# Altium schematic sheet MCU_Power.SchDoc(MCU_Power)
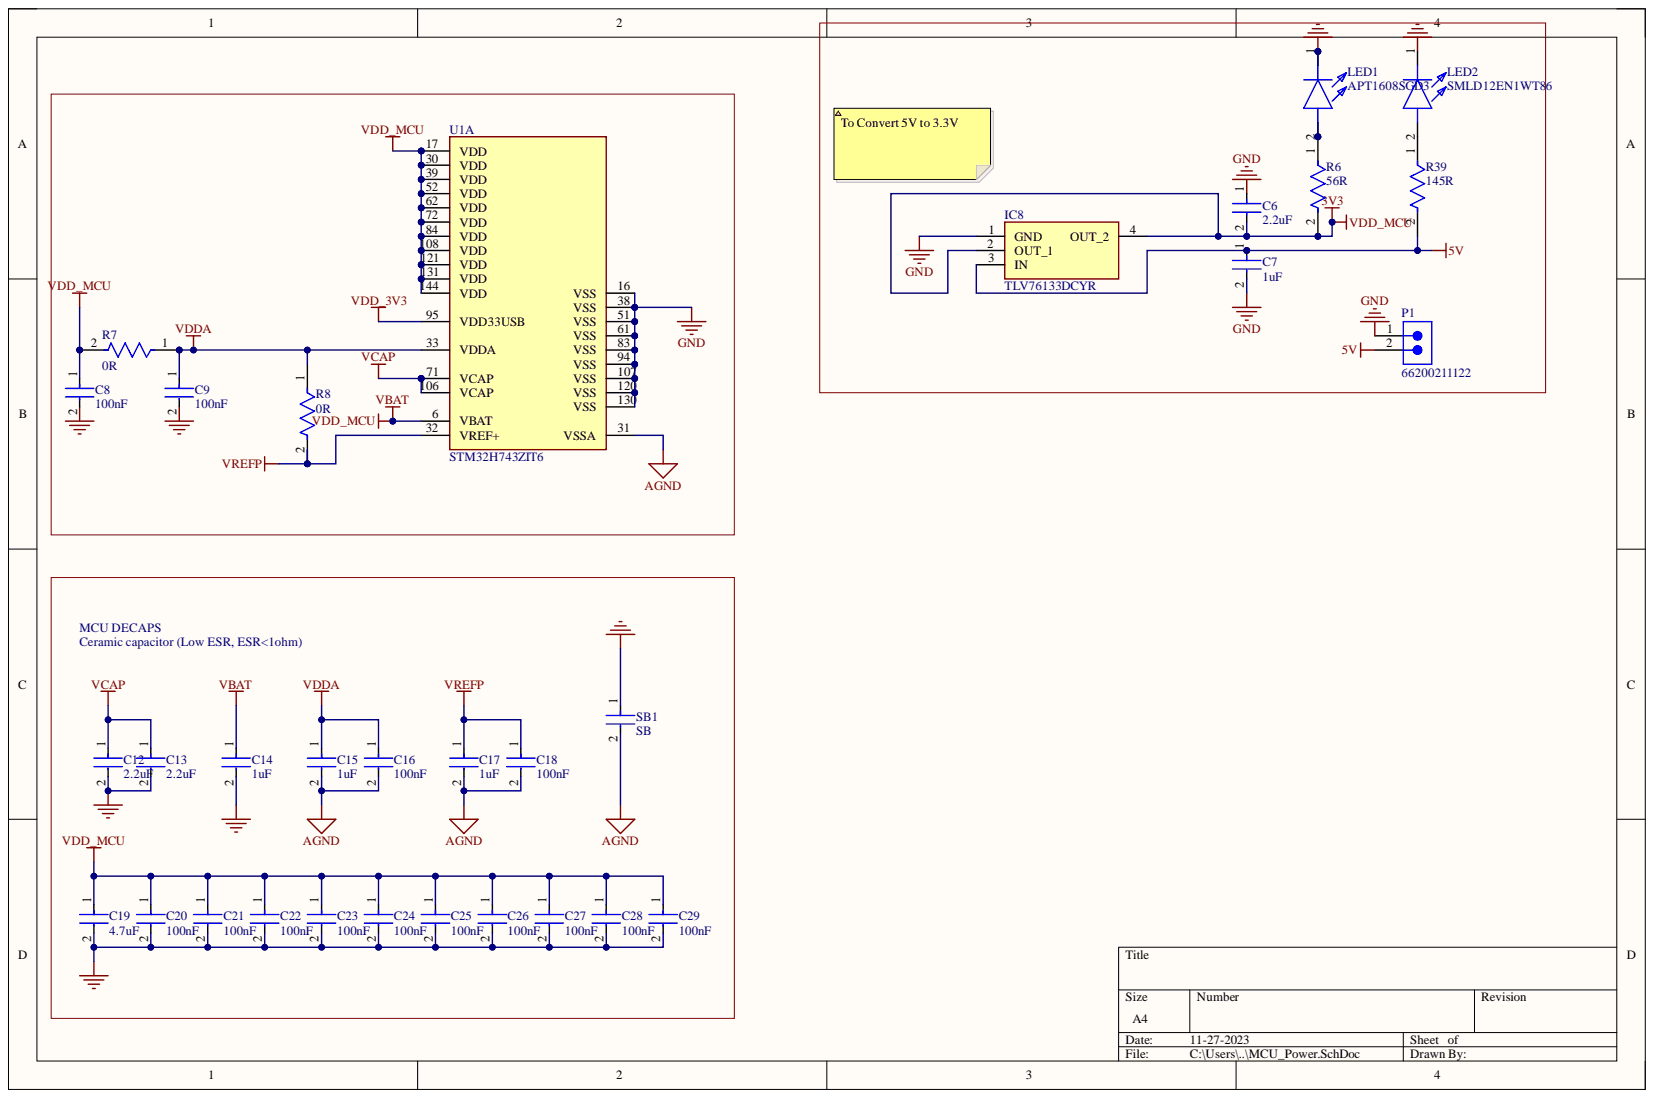
[diagram p0: MCU_Power.SchDoc]

## Parts
C6  2.2uF  [FP-C0603C-CF-MFG]
C7  1uF  [FP-C0603C-CF-MFG]
C8  100nF  [FP-C0402-BB-MFG]
C9  100nF  [FP-C0402-BB-MFG]
C12  2.2uF  [FP-C0603C-CF-MFG]
C13  2.2uF  [FP-C0603C-CF-MFG]
C14  1uF  [FP-C0603C-CF-MFG]
C15  1uF  [FP-C0603C-CF-MFG]
C16  100nF  [FP-C0603C-CF-MFG]
C17  1uF  [FP-C0603C-CF-MFG]
C18  100nF  [FP-C0603C-CF-MFG]
C19  4.7uF  [FP-C0603C-CF-MFG]
C20  100nF  [FP-C0402-BB-MFG]
C21  100nF  [FP-C0402-BB-MFG]
C22  100nF  [FP-C0402-BB-MFG]
C23  100nF  [FP-C0402-BB-MFG]
C24  100nF  [FP-C0402-BB-MFG]
C25  100nF  [FP-C0402-BB-MFG]
C26  100nF  [FP-C0402-BB-MFG]
C27  100nF  [FP-C0402-BB-MFG]
C28  100nF  [FP-C0402-BB-MFG]
C29  100nF  [FP-C0402-BB-MFG]
IC8  TLV76133DCYR  [SOT230P700X180-4N]
LED1  APT1608SGD3  [LEDC1608X85N]
LED2  SMLD12EN1WT86  [LEDM168X65N]
P1  66200211122  [66200211122]
R6  56R  [FP-RT0603-MFG]
R7  0R  [FP-RT0603-MFG]
R8  0R  [FP-RT0603-MFG]
R39  145R  [FP-RT0603-MFG]
SB1  SB  [FP-C0603C-CF-MFG]
U1  STM32H743ZIT6  [FP-LQFP144-1A-MFG]  (part A)

## Nets
3V3: C6.2 C8.1 C14.1 C19.1 C20.1 C21.1 C22.1 C23.1 C24.1 C25.1 C26.1 C27.1 C28.1 C29.1 IC8.2(OUT_1) IC8.4(OUT_2) R6.2 R7.2 U1.6(VBAT) U1.17(VDD) U1.30(VDD) U1.39(VDD) U1.52(VDD) U1.62(VDD) U1.72(VDD) U1.84(VDD) U1.108(VDD) U1.121(VDD) U1.131(VDD) U1.144(VDD)
5V: C7.1 IC8.3(IN) P1.2 R39.2
AGND: C15.2 C16.2 C17.2 C18.2 SB1.2 U1.31(VSSA)
GND: C6.1 C7.2 C8.2 C9.2 C12.2 C13.2 C14.2 C19.2 C20.2 C21.2 C22.2 C23.2 C24.2 C25.2 C26.2 C27.2 C28.2 C29.2 IC8.1(GND) LED1.1 LED2.1 P1.1 SB1.1 U1.16(VSS) U1.38(VSS) U1.51(VSS) U1.61(VSS) U1.83(VSS) U1.94(VSS) U1.107(VSS) U1.120(VSS) U1.130(VSS)
VCAP: C12.1 C13.1 U1.71(VCAP) U1.106(VCAP)
VDD_3V3: U1.95(VDD33USB)
VDDA: C9.1 C15.1 C16.1 R7.1 R8.1 U1.33(VDDA)
VREFP: C17.1 C18.1 R8.2 U1.32(VREF+)
NetLED1_2: LED1.2 R6.1
NetLED2_2: LED2.2 R39.1

## Library data of the parts
C6 C7 C12-C19 = CMP-2006-03061-2: CAP CER 0.1UF 50V X7R 0603; Manufacturer: KEMET; Manufacturer Part Number: C0603C104K5RACTU; Automotive Grade: Grade 1; Capacitance: 0.10 μF; Material: Ceramic; Temperature Coefficient: 30ppm/°C; Tolerance: 0.1; Value: 0.10 μF; Automotive: Yes; Category: Cap; Component Kind: Standard; ComponentLink2Description: Datasheet; ComponentLink2URL: https://api.kemet.com/component-edge/download/datasheet/C0603C100J5GACAUTO.pdf; Device Class L1: Passive Components; Device Class L2: Capacitors; Device Class L3: Ceramic Capacitors; Digikey Description: CAP CER 0.1UF 50V X7R 0603; Height: 0.87mm; Lead Free: Yes; Library Name: Altium Content Vault; Octopart: https://octopart.com/c0603c104k5ractu-kemet-139244; Package: C0603C-CF; RoHS: TRUE; Temperature: -55°C to +125°C; Temperature Characteristic: X7R; Temperature Range High: +125°C; Temperature Range Low: -55°C; Voltage Rating: 50 V
C8 C9 C20-C29 = CMP-025-000008-1: CAP CER 0.1UF 10V X5R 0402; Manufacturer: KEMET; Manufacturer Part Number: C0402C104K8PACTU; Capacitance: 0.10 µF; Material: Ceramic; Temperature Coefficient: 15%; Tolerance: 0.1; Value: 0.10 µF; Automotive: No; Category: Cap; Component Kind: Standard; Component Type: Standard; Design Item ID: CMP-2008-02046-2; Device Class L1: Passive Components; Device Class L2: Capacitors; Device Class L3: Ceramic Capacitors; Digikey Description: CAP CER 0.1UF 10V X5R 0402; Height: 0.55mm; Ipc Land Pattern Name: CAPC10050X50; Lead Free: Yes; Library Name: DH08; Mouser Description: Capacitor 100 nF 10 VDC 0402; Octopart: https://octopart.com/c0402c104k8pactu-kemet-140039; Package: C0402-BB; Pin Count: 2; RoHS: TRUE; Simulation: C_Capacitor_Default; Temperature: -55°C to +125°C; Temperature Characteristic: X5R; Temperature Range High: +125°C; Temperature Range Low: -55°C; Voltage Rating: 10 V; Source: DH07_Optical_Localization_Sensor_V2_Main_1.SCHLIB
IC8 = TLV76133DCYR: Integrated Circuit; Manufacturer_Name: Texas Instruments; Arrow Part Number: TLV76133DCYR; Arrow Price/Stock: https://www.arrow.com/en/products/tlv76133dcyr/texas-instruments?region=nac; Component Kind: Standard; Datasheet Link: https://www.ti.com/lit/ds/symlink/tlv761.pdf?ts=1679984111185&ref_url=https%253A%252F%252Fwww.ti.com%252Fsitesearch%252Fen-us%252Fdocs%252Funiversalsearch.tsp%253FlangPref%253Den-US%2526searchTerm%253DTLV76133DCYR%2526nr%253D2; Height: 1.8mm; Manufacturer_Part_Number: TLV76133DCYR; Mouser Part Number: 595-TLV76133DCYR; Mouser Price/Stock: https://www.mouser.co.uk/ProductDetail/Texas-Instruments/TLV76133DCYR?qs=9vOqFld9vZV5BPjUhjTZUA%3D%3D
LED1 = APT1608SGD3: LED; Manufacturer_Name: Kingbright; Arrow Part Number: APT1608SGD3; Arrow Price/Stock: https://www.arrow.com/en/products/apt1608sgd3/kingbright; Component Kind: Standard; Datasheet Link: https://www.mouser.com/datasheet/2/216/APT1608SGD3(Ver.1B)-1173264.pdf; Height: 0.85mm; Manufacturer_Part_Number: APT1608SGD3; Mouser Part Number: 604-APT1608SGD3; Mouser Price/Stock: https://www.mouser.co.uk/ProductDetail/Kingbright/APT1608SGD3?qs=3tEdVX3iVSWm0Dk%2F4%2FVu6w%3D%3D
LED2 = SMLD12EN1WT86: LED; Manufacturer_Name: ROHM Semiconductor; Component Kind: Standard; Datasheet Link: https://datasheet.datasheetarchive.com/originals/distributors/Datasheets_SAMA/8f824a900f8c9a143b1c3640e6aee7b7.pdf; Height: 0.65mm; Manufacturer_Part_Number: SMLD12EN1WT86; Mouser Part Number: 755-SMLD12EN1WT86; Mouser Price/Stock: https://www.mouser.co.uk/ProductDetail/ROHM-Semiconductor/SMLD12EN1WT86?qs=qSfuJ%252Bfl%2Fd4fR%252B9yZydIwg%3D%3D
P1 = CMP-1502-00440-2: NexarPartId: 23024676; Connector Type: Male Pin, Socket; Contact Resistance: 10mΩ; Current Rating: 5A; Gender: Male; Insulation Resistance: 1GΩ; Number of Contacts: 2; Operating Supply Voltage: 250V; Pitch: 3mm; Color: Black; Component Kind: Standard; Contact Material: Copper; Contact Plating: Tin; Fastening Type: Ramp; Height: 10.1mm; Lead Pitch: 3mm; Length: 9.65mm; Library Name: Altium Content Vault; Max Operating Temperature: 105°C; Min Operating Temperature: -40°C; Mount: Through Hole; Number of Pins: 2; Number of Positions: 2; Number of Rows: 1; Orientation: Vertical; REACH SVHC: Unknown; RoHS: Compliant; Termination: Kinked Pin; Voltage Rating: 250V; Width: 9.65mm
R6 R39 = CMP-030-000001-1: RES SMD 10K OHM 1% 1/10W 0603; Manufacturer: Yageo; Manufacturer Part Number: RT0603FRE0710KL; Power Rating: 0.1W; Resistance: 10KO; Temperature Coefficient: 50 ppm/°C; Tolerance: 0.01; Value: 10KO; Voltage Rating: 75V; Automotive: No; Category: Res; Component Kind: Standard; Component Type: Standard; Composition: Thin Film; Design Item ID: CMP-03412-005349-1; Device Class L1: Passive Components; Device Class L2: Resistors; Device Class L3: Chip SMD Resistors; Digikey Description: RES SMD 10K OHM 1% 1/10W 0603; Footprint Url: http://www.yageo.com/exep/pages/download/literatures/PYu-R_Mount_10.pdf; Height: 0.55mm; Ipc Land Pattern Name: RESC16080X45; Lead Free: Yes; Library Name: DH08; Mouser Description: Thin Film Resistors - SMD 10K ohm 1% 1/10W; Octopart: https://octopart.com/rt0603fre0710kl-yageo-40009243; Package: RT0603; Pin Count: 2; RoHS: TRUE; Series: RT; Temperature: -55°C to +155°C; Temperature Range High: +155°C; Temperature Range Low: -55°C; Source: DH07_Optical_Localization_Sensor_V2_Main_1.SCHLIB
R7 R8 = CMP-03412-033768-1: RES SMD 2.2K OHM 1% 1/10W 0603; Manufacturer: Yageo; Manufacturer Part Number: RT0603FRE072K2L; Power Rating: 0.1W; Resistance: 2.2KΩ; Temperature Coefficient: 50 ppm/°C; Tolerance: 0.01; Value: 2.2KΩ; Voltage Rating: 75V; Automotive: No; Category: Res; Component Kind: Standard; ComponentLink2Description: Datasheet; ComponentLink2URL: http://www.yageo.com/documents/recent/PYu-RT_1-to-0.01_RoHS_L_9.pdf; Composition: Thin Film; Device Class L1: Passive Components; Device Class L2: Resistors; Device Class L3: Chip SMD Resistors; Digikey Description: RES SMD 2.2K OHM 1% 1/10W 0603; Footprint Url: http://www.yageo.com/exep/pages/download/literatures/PYu-R_Mount_10.pdf; Height: 0.55mm; Ipc Land Pattern Name: RESC16080X45; Lead Free: Yes; Library Name: Altium Content Vault; Mouser Description: High Precision-High Stability Chip Resistor Thin Film 0603 2.2kOhm 1% Paper T/R; Octopart: https://octopart.com/rt0603fre072k2l-yageo-40019811; Package: RT0603; RoHS: TRUE; Series: RT; Temperature: -55°C to +155°C; Temperature Range High: +155°C; Temperature Range Low: -55°C
SB1 = CMP-2006-03061-2: CAP CER 0.1UF 50V X7R 0603; Manufacturer: KEMET; Manufacturer Part Number: C0603C104K5RACTU; Automotive Grade: Grade 1; Capacitance: 0.10 μF; Temperature Coefficient: 30ppm/°C; Tolerance: 0.1; Value: 0.10 μF; Automotive: Yes; Category: Cap; Component Kind: Standard; ComponentLink2Description: Datasheet; ComponentLink2URL: https://api.kemet.com/component-edge/download/datasheet/C0603C100J5GACAUTO.pdf; Device Class L1: Passive Components; Device Class L2: Capacitors; Device Class L3: Ceramic Capacitors; Digikey Description: CAP CER 0.1UF 50V X7R 0603; Height: 0.87mm; Lead Free: Yes; Library Name: Altium Content Vault; Material: Ceramic; Octopart: https://octopart.com/c0603c104k5ractu-kemet-139244; Package: C0603C-CF; RoHS: TRUE; Temperature: -55°C to +125°C; Temperature Characteristic: X7R; Temperature Range High: +125°C; Temperature Range Low: -55°C; Voltage Rating: 50 V
U1 = CMP-12105-000003-1: IC MCU 32BIT 2MB FLASH 144LQFP; Manufacturer: STMicroelectronics; Core Architecture: ARM Cortex-M7; Data Bus Width: 32bits; Frequency: 400MHz; Interface: I2C,SPI,UART,USART,EBI/EMI,CAN,USB,LIN,IrDA,Other; Max Junction Temp: +125°C; Max Supply Voltage: 3.6V; Memory Size: 2MBytes; Min Supply Voltage: 1.62V; Number Of A/D Converters: 2; Number Of Adc Channels: 36; Number Of Cores: 1; Number Of I2C Channels: 4; Number Of I/Os: 114; Number Of Spi Channels: 6; Number Of Timers/Counters: 22; Ram Size: 1MBytes; Standoff Height: 0.05mm; Ambient Temperature Range High: +85°C; Ambient Temperature Range Low: -40°C; Automotive: No; Category: IC; Component Kind: Standard; ComponentLink2Description: Datasheet; ComponentLink2URL: https://www.st.com/resource/en/datasheet/stm32h743zi.pdf; Device Class L1: Integrated Circuits (ICs); Device Class L2: Embedded Processors and Controllers; Device Class L3: Microcontrollers; Digikey Description: IC MCU 32BIT 2MB FLASH 144LQFP; Height: 1.6mm; Ipc Land Pattern Name: QFP50P2200X2200X160-144; Lead Free: Yes; Library Name: Altium Content Vault; Manufacturer Part Number: STM32H743ZIT6; Memory Type: FLASH; Mouser Description: MCU 32-Bit STM32 ARM Cortex M7 RISC 2MB Flash 1.8V/2.5V/3.3V 144-Pin LQFP Tray; Number Of Pwm Channels: 2; Number Of Uart Channels: 4; Number Of Usb Channels: 2; Octopart: https://octopart.com/stm32h743zit6-stmicroelectronics-76372529; Package: LQFP144-1A; RoHS: TRUE; Temperature: -40°C to +85°C; Temperature Range High: +85°C; Temperature Range Low: -40°C
